# Altium schematic sheet 12V_VMOT_SUPPLY.SchDoc
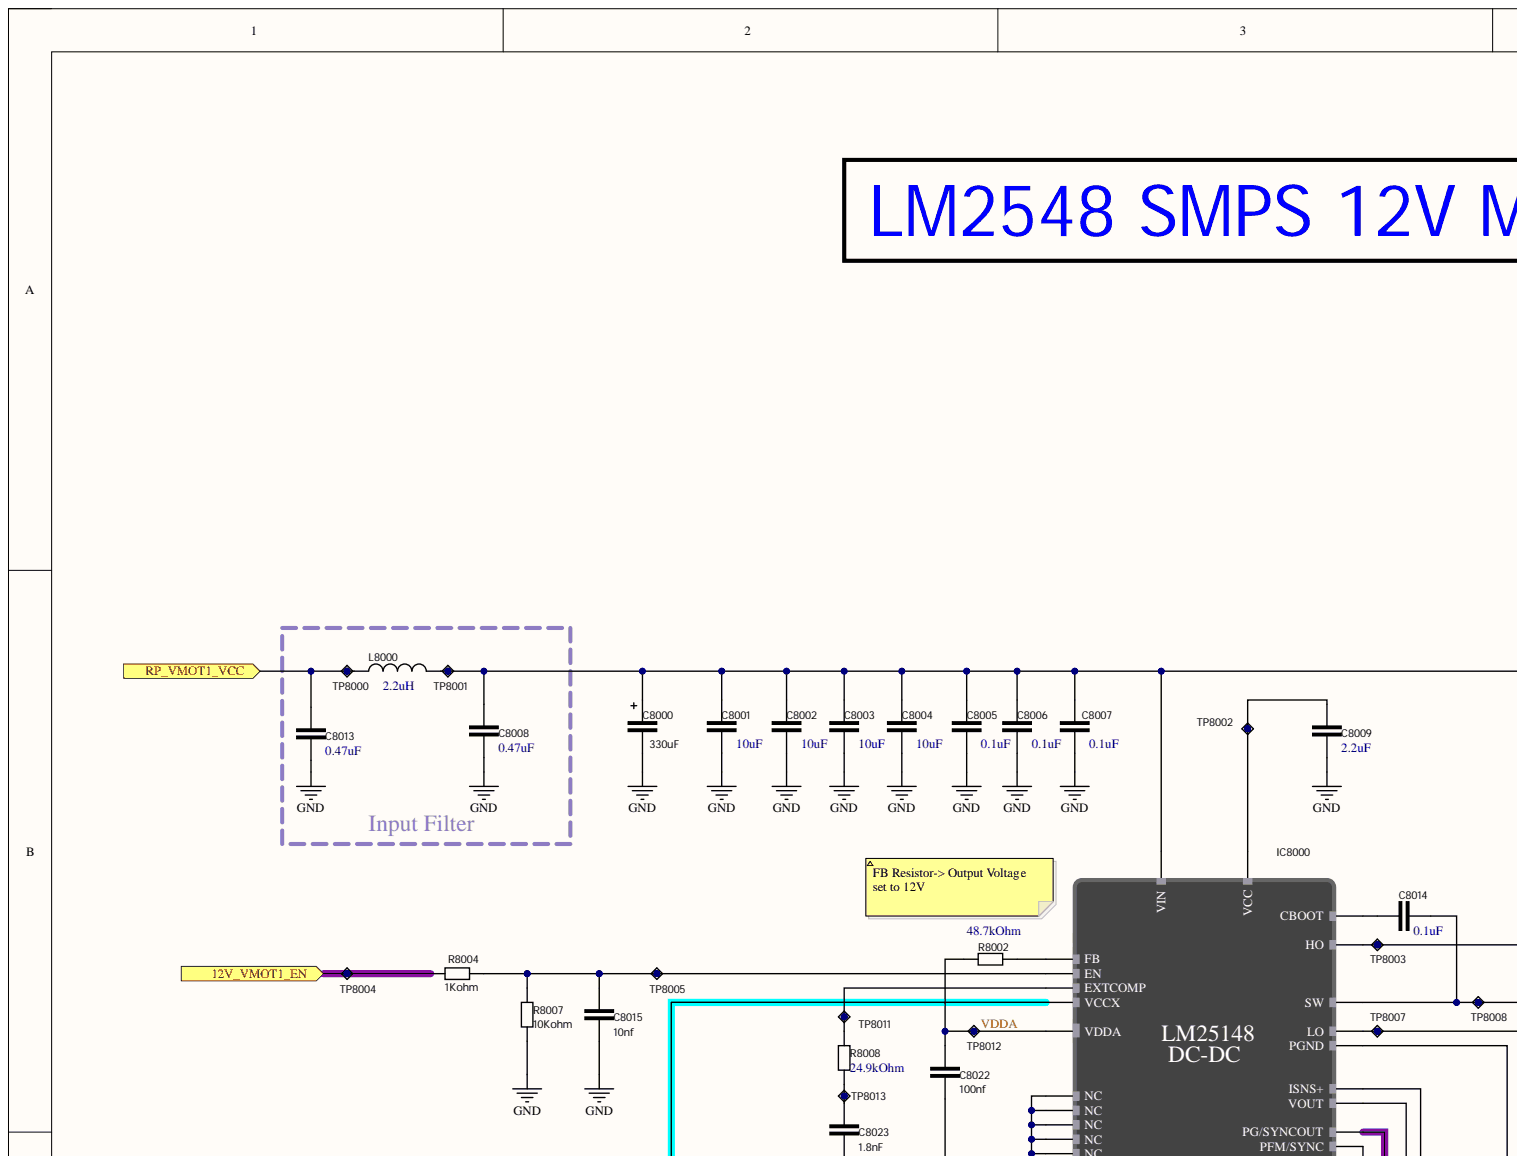
[diagram p0: 12V_VMOT_SUPPLY.SchDoc, top left]
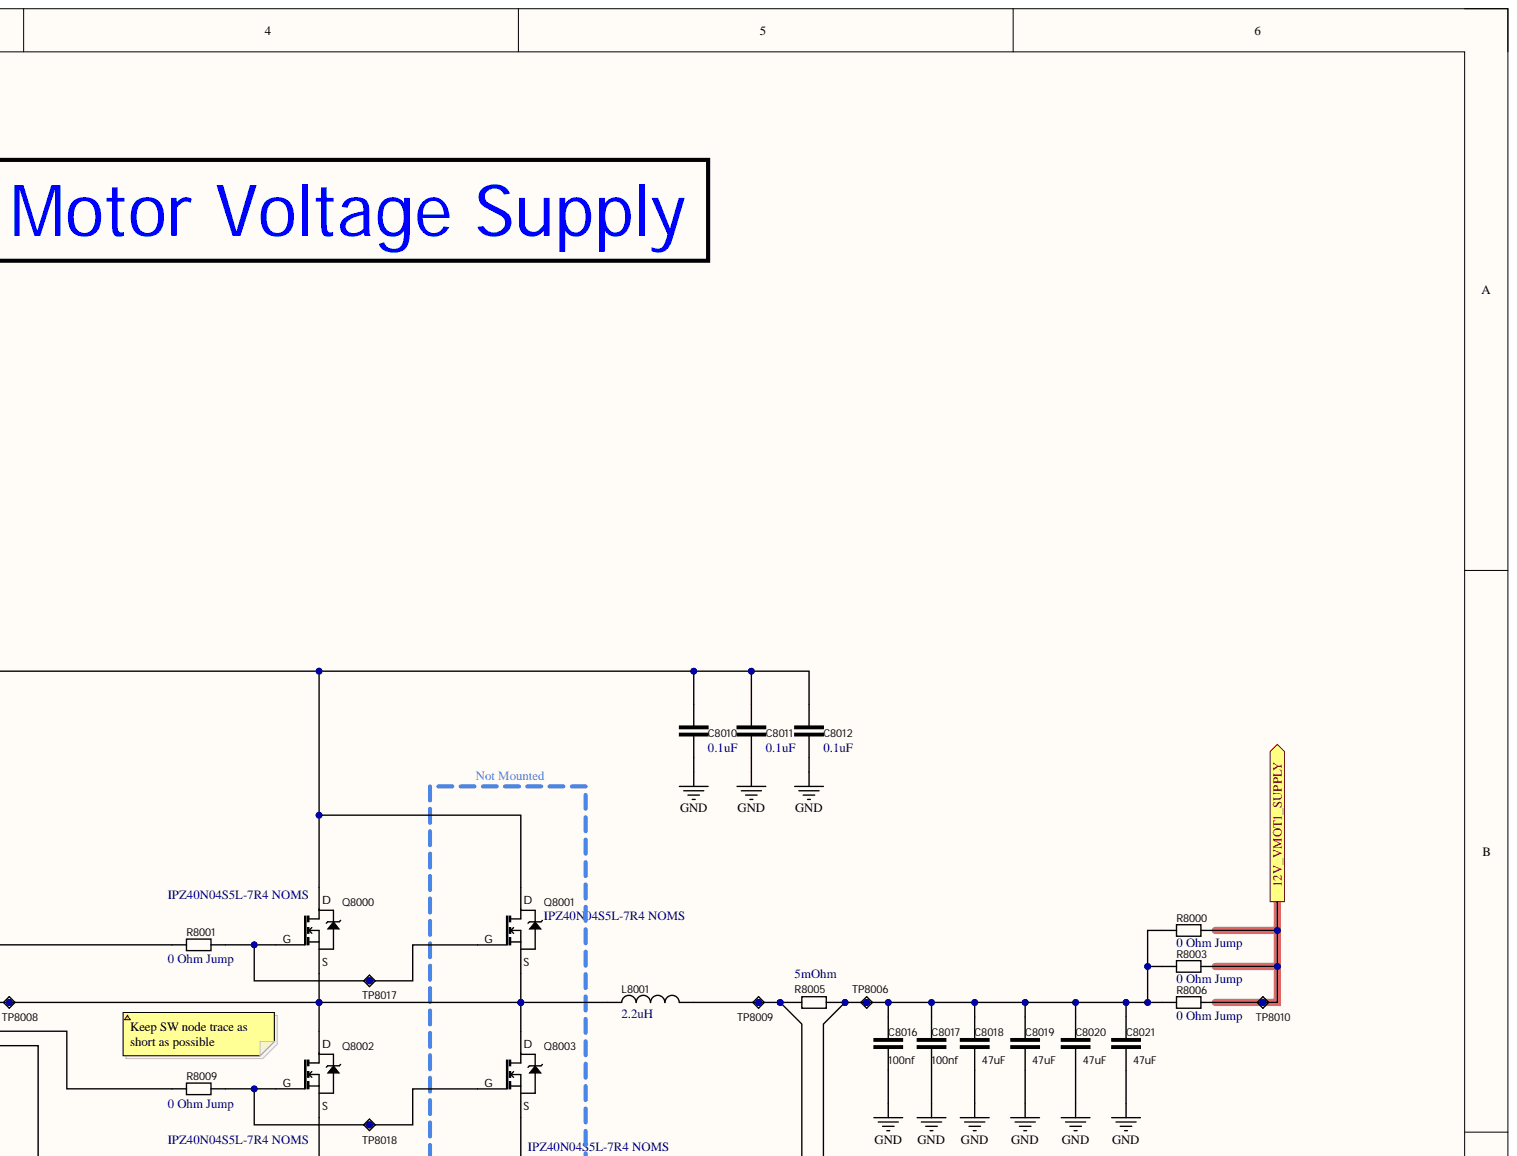
[diagram p1: 12V_VMOT_SUPPLY.SchDoc, top right]
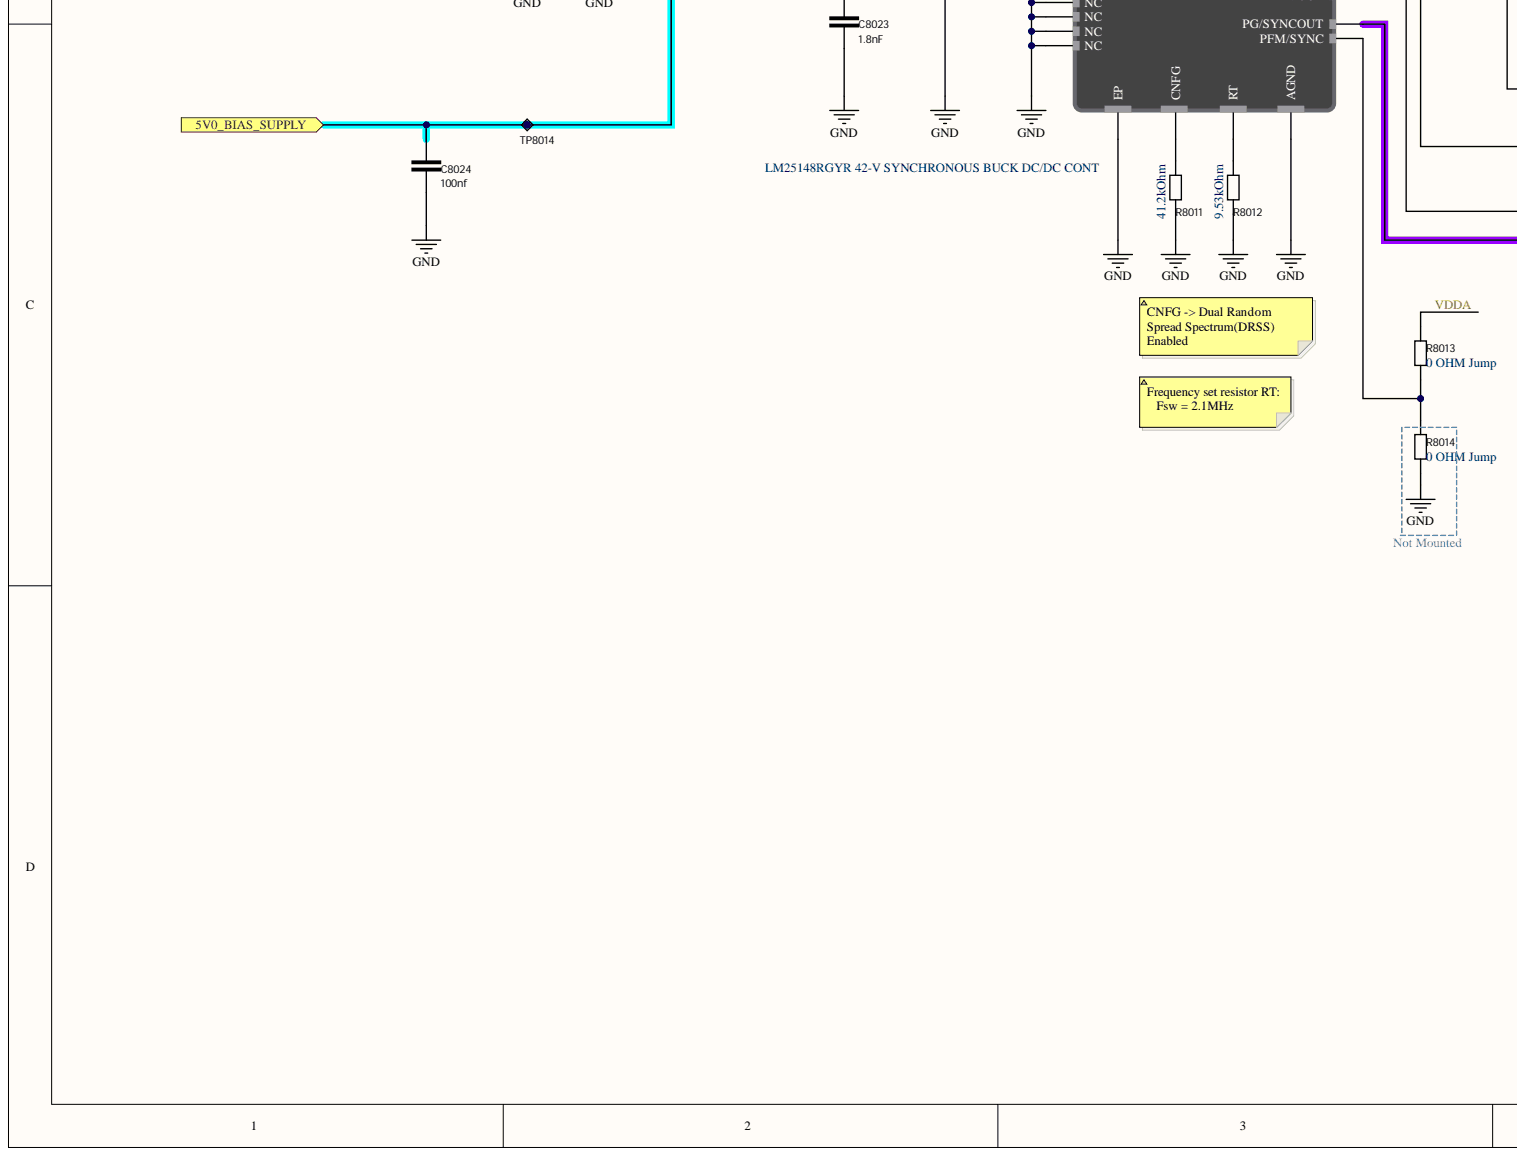
[diagram p2: 12V_VMOT_SUPPLY.SchDoc, bottom left]
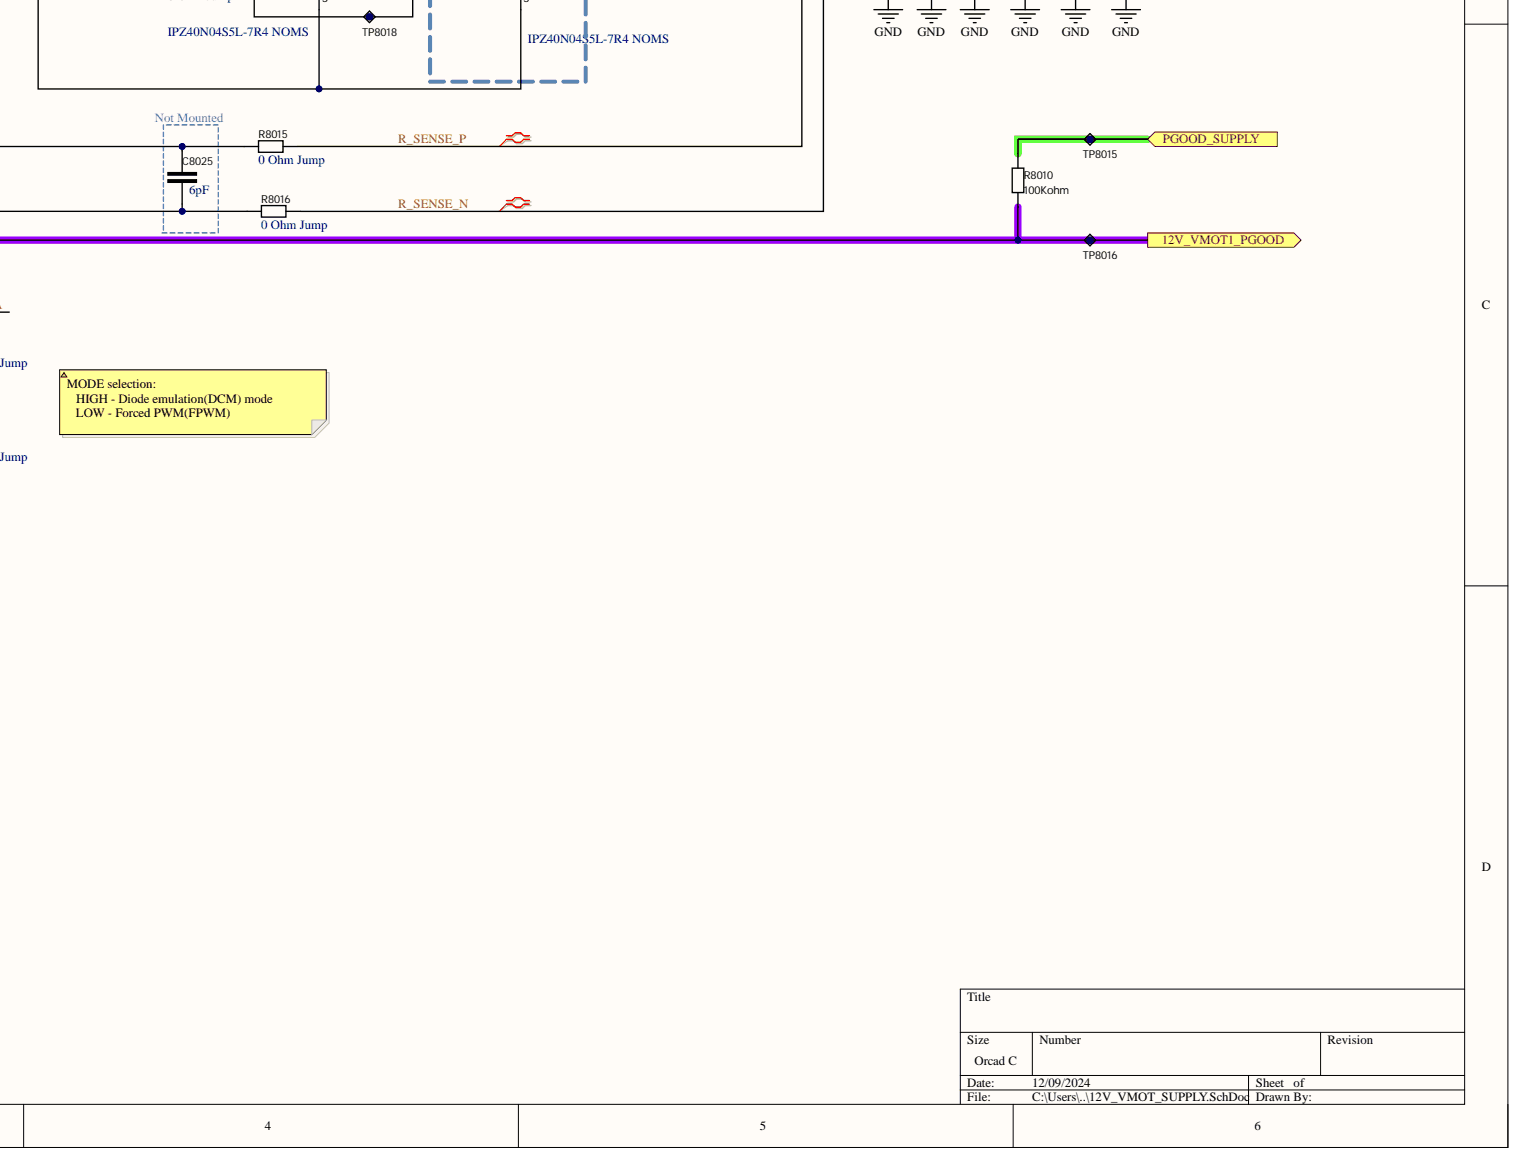
[diagram p3: 12V_VMOT_SUPPLY.SchDoc, bottom right]

## Parts
C8000  330uF  [CAPAE109103X103M]
C8001  10uF  [CAP_1206]
C8002  10uF  [CAP_1206]
C8003  10uF  [CAP_1206]
C8004  10uF  [CAP_1206]
C8005  0.1uF  [CAP_0603]
C8006  0.1uF  [CAP_0603]
C8007  0.1uF  [CAP_0603]
C8008  0.47uF  [CAP_0805]
C8009  2.2uF  [CAP_0603]
C8010  0.1uF  [CAP_0603]
C8011  0.1uF  [CAP_0603]
C8012  0.1uF  [CAP_0603]
C8013  0.47uF  [CAP_0805]
C8014  0.1uF  [CAP_0603]
C8015  10nf  [CAP_0603]
C8016  100nf  [CAP_0603]
C8017  100nf  [CAP_0603]
C8018  47uF  [CAP_1210]
C8019  47uF  [CAP_1210]
C8020  47uF  [CAP_1210]
C8021  47uF  [CAP_1210]
C8022  100nf  [CAP_0603]
C8023  1.8nF  [CAP_0603]
C8024  100nf  [CAP_0603]
C8025  6pF  [CAP_0402]
IC8000  LM25148RGYR 42-V SYNCHRONOUS BUCK DC/DC CONT  [VQFN25_RGY_TEX]
L8000  2.2uH  [INDP2523X23N_4040]
L8001  2.2uH  [INDP2523X23N_4040]
Q8000  IPZ40N04S5L-7R4 NOMS  [PG-TSDSON-8-32]
Q8001  IPZ40N04S5L-7R4 NOMS  [PG-TSDSON-8-32]
Q8002  IPZ40N04S5L-7R4 NOMS  [PG-TSDSON-8-32]
Q8003  IPZ40N04S5L-7R4 NOMS  [PG-TSDSON-8-32]
R8000  0 Ohm Jump  [RES_1206]
R8001  0 Ohm Jump  [RES_0402]
R8002  48.7kOhm  [RES_0603]
R8003  0 Ohm Jump  [RES_1206]
R8004  1Kohm  [RES_0603]
R8005  5mOhm  [RES_0508_wide]
R8006  0 Ohm Jump  [RES_1206]
R8007  10Kohm  [RES_0603]
R8008  24.9kOhm  [RES_0603]
R8009  0 Ohm Jump  [RES_0402]
R8010  100Kohm  [RES_0603]
R8011  41.2kOhm  [RES_0603]
R8012  9.53kOhm  [RES_0603]
R8013  0 OHM Jump  [RES_0603]
R8014  0 OHM Jump  [RES_0603]
R8015  0 Ohm Jump  [RES_0402]
R8016  0 Ohm Jump  [RES_0402]
TP8000  Test point  [TestPoint]  (value not drawn)
TP8001  Test point  [TestPoint]  (value not drawn)
TP8002  Test point  [TestPoint]  (value not drawn)
TP8003  Test point  [TestPoint]  (value not drawn)
TP8004  Test point  [TestPoint]  (value not drawn)
TP8005  Test point  [TestPoint]  (value not drawn)
TP8006  Test point  [TestPoint]  (value not drawn)
TP8007  Test point  [TestPoint]  (value not drawn)
TP8008  Test point  [TestPoint]  (value not drawn)
TP8009  Test point  [TestPoint]  (value not drawn)
TP8010  Test point  [TestPoint]  (value not drawn)
TP8011  Test point  [TestPoint]  (value not drawn)
TP8012  Test point  [TestPoint]  (value not drawn)
TP8013  Test point  [TestPoint]  (value not drawn)
TP8014  Test point  [TestPoint]  (value not drawn)
TP8015  Test point  [TestPoint]  (value not drawn)
TP8016  Test point  [TestPoint]  (value not drawn)
TP8017  Test point  [TestPoint]  (value not drawn)
TP8018  Test point  [TestPoint]  (value not drawn)

## Ports
5V0_BIAS_SUPPLY, 12V_VMOT1_EN, 12V_VMOT1_PGOOD, 12V_VMOT1_SUPPLY, PGOOD_SUPPLY, RP_VMOT1_VCC

## Nets
GND: C8000.1 C8001.1 C8002.2 C8003.2 C8004.2 C8005.2 C8006.2 C8007.1 C8008.1 C8009.1 C8010.1 C8011.1 C8012.2 C8013.1 C8015.1 C8016.1 C8017.1 C8018.1 C8019.1 C8020.1 C8021.1 C8022.1 C8023.1 C8024.1 IC8000.1(NC) IC8000.2(NC) IC8000.7(AGND) IC8000.22(NC) IC8000.23(NC) IC8000.24(NC) IC8000.25(EP) R8007.1 R8011.1 R8012.1 R8014.1
5V0_BIAS_SUPPLY: C8024.2 IC8000.16(VCCX) TP8014.1 ; port 5V0_BIAS_SUPPLY
12V_VMOT1_EN: R8004.1 TP8004.1 ; port 12V_VMOT1_EN
12V_VMOT1_PGOOD: IC8000.17(PG/SYNCOUT) R8010.1 TP8016.1 ; port 12V_VMOT1_PGOOD
12V_VMOT1_SUPPLY: R8000.2 R8003.2 R8006.2 TP8010.1 ; port 12V_VMOT1_SUPPLY
PGOOD_SUPPLY: R8010.2 TP8015.1 ; port PGOOD_SUPPLY
R_SENSE_8_N: C8016.2 C8017.2 C8018.2 C8019.2 C8020.2 C8021.2 R8000.1 R8003.1 R8005.2 R8006.1 R8016.2 TP8006.1  [also labelled R_SENSE_N]
R_SENSE_8_P: L8001.2 R8005.1 R8015.2 TP8009.1  [also labelled R_SENSE_P]
RP_VMOT1_VCC: C8013.2 L8000.1 TP8000.1 ; port RP_VMOT1_VCC
VDDA_8: C8022.2 IC8000.8(VDDA) R8002.1 R8013.2 TP8012.1  [also labelled VDDA]
NetC8000_2: C8000.2 C8001.2 C8002.1 C8003.1 C8004.1 C8005.1 C8006.1 C8007.2 C8008.2 C8010.2 C8011.2 C8012.1 IC8000.12(VIN) L8000.2 Q8000.D Q8001.D TP8001.1
NetC8009_2: C8009.2 IC8000.9(VCC) TP8002.1
NetC8014_1: C8014.1 IC8000.14(SW) L8001.1 Q8000.S Q8001.S Q8002.D Q8003.D TP8008.1
NetC8014_2: C8014.2 IC8000.15(CBOOT)
NetC8015_2: C8015.2 IC8000.19(EN) R8004.2 R8007.2 TP8005.1
NetC8023_2: C8023.2 R8008.1 TP8013.1
NetC8025_1: C8025.1 IC8000.21(VOUT) R8016.1
NetC8025_2: C8025.2 IC8000.20(ISNS+) R8015.1
NetIC8000_3: IC8000.3(CNFG) R8011.2
NetIC8000_4: IC8000.4(RT) R8012.2
NetIC8000_5: IC8000.5(EXTCOMP) R8008.2 TP8011.1
NetIC8000_6: IC8000.6(FB) R8002.2
NetIC8000_10: IC8000.10(PGND) Q8002.S Q8003.S
NetIC8000_11: IC8000.11(LO) R8009.1 TP8007.1
NetIC8000_13: IC8000.13(HO) R8001.1 TP8003.1
NetIC8000_18: IC8000.18(PFM/SYNC) R8013.1 R8014.2
NetQ8000_G: Q8000.G Q8001.G R8001.2 TP8017.1
NetQ8002_G: Q8002.G Q8003.G R8009.2 TP8018.1

## Library data of the parts
C8000 = C000031: 330 µF 35 V Aluminum Electrolytic Capacitors Radial, Can - SMD 90mOhm @ 100kHz 2000 Hrs @ 105°C; capaticanse: 330uF; Component Kind: Standard; ComponentLink1Description: digikey; ComponentLink1URL: https://www.digikey.com/en/products/detail/nic-components-corp/NACZ331M35V10X10-5TR15F/22237831?s=N4IgjCBcoGwJxVAYygMwIYBsDOBTANCAPZQDaIALAAxwDMdIAuoQA4AuUIAymwE4CWAOwDmIAL6EA7ACYKiECkgYcBYmRDS4cSfCasOkbnyGiJGqhQTQFaLHkIlI5WrSoACAK0AxPSHacAVUF%2BNgB5VABZXHRsAFdeXHFCaSpJK2RbFQd1AFZ3ADUQQloct0LmPwMQIJDwqJj4xLMAWml5RT5Y1UdyHKYxMzanBXQWdCQQol5%2BoA; DigiKey part number: 4988-NACZ331M35V10X10.5TR15FCT-ND; Library Name: CapacitorsIntegrated.IntLib
C8001-C8004 = C000017: CAP CER 10UF 50V X7R 1206; capacitance: 10uF; Component Kind: Standard; ComponentLink1Description: DigiKey; ComponentLink1URL: https://www.digikey.com/en/products/detail/samsung-electro-mechanics/CL31B106KBHNNNE/5961251; DigiKey Part Number: 1276-6767-1-ND; Library Name: CapacitorsIntegrated.IntLib
C8005-C8007 C8010-C8012 C8014 = C000018: CAP CER 0.1UF 50V X7R 0603; capacitance: 0.1uF; Component Kind: Standard; ComponentLink1Description: DigiKey; ComponentLink1URL: https://www.digikey.com/en/products/detail/samsung-electro-mechanics/CL10B104KB8NNNC/3886658; DigiKey Part Number: 1276-1000-1-ND; Library Name: CapacitorsIntegrated.IntLib
C8008 C8013 = C000030: 0.47 µF ±10% 50V Ceramic Capacitor X7R 0805 (2012 Metric); capacitance: 0.47uF; Component Kind: Standard; ComponentLink1Description: DigiKey; ComponentLink1URL: https://www.digikey.com/en/products/detail/murata-electronics/GRM21BR71H474KA88L/702592; DigiKey Part Number: 490-3328-1-ND; Library Name: CapacitorsIntegrated.IntLib
C8009 = C000014: CAP CER 2.2UF 16V X7S 0603; capaticanse: 2.2uF; Component Kind: Standard; ComponentLink1Description: Digikey; ComponentLink1URL: https://www.digikey.com/en/products/detail/murata-electronics/GRM188C71C225KE11D/4905311; Digikey Part Number: 490-12731-1-ND; Library Name: CapacitorsIntegrated.IntLib
C8015 = C000005: CAP CER 10nF 0603 25V X7R; capaticanse: 10nf; Component Kind: Standard; ComponentLink1Description: digikey; ComponentLink1URL: https://www.digikey.com/en/products/detail/kyocera-avx/06033C103MAT2A/1599370; Library Name: CapacitorsIntegrated.IntLib
C8016 C8017 C8022 C8024 = C000007: CAP CER 100nF 0603 25V X7R; capaticanse: 100nf; Component Kind: Standard; ComponentLink1Description: digikey; ComponentLink1URL: https://www.digikey.com/en/products/detail/kyocera-avx/06033C104K4T2A/8019250; Library Name: CapacitorsIntegrated.IntLib
C8018-C8021 = C000028: 47 µF ±20% 25V Ceramic Capacitor X5R 1210 (3225 Metric); capaticanse: 47uF; Component Kind: Standard; ComponentLink1Description: DigiKey; ComponentLink1URL: https://www.digikey.com/en/products/detail/taiyo-yuden/MSAST32MAB5476MPNDT1/16661322?s=N4IgTCBcDaILIGUCCCAqBmMckCECsALAOwBscACgHIAiqAjCALoC%2BQA; ComponentLink2Description: Mouser; ComponentLink2URL: https://eu.mouser.com/ProductDetail/TAIYO-YUDEN/MSAST32MAB5476MPNDT1?qs=tlsG%2FOw5FFjVcfO8xtWwSA%3D%3D; DigiKey Part Number: 587-MSAST32MAB5476MPNDT1CT-ND; Library Name: CapacitorsIntegrated.IntLib; Mouser Part Number: 963-MSAST32MAB5476MP
C8023 = C000027: 1800 pF ±10% 25V Ceramic Capacitor X7R 0603 (1608 Metric); capaticanse: 1.8nF; Component Kind: Standard; ComponentLink1Description: digikey; ComponentLink1URL: https://www.digikey.com/en/products/detail/kemet/C0603C182K3RAC7867/2200107; DigiKey Part Number: 399-15370-1-ND; Library Name: CapacitorsIntegrated.IntLib
C8025 = C000016: CAP CER 6PF 25V C0G/NP0 0402; capacitanse: 6pF; Component Kind: Standard; ComponentLink1Description: DigiKey; ComponentLink1URL: https://www.digikey.com/en/products/detail/kemet/CBR04C609D3GAC/18137865?s=N4IgjCBcpgLFoDGUBmBDANgZwKYBoQB7KAbXAFYBOAJlgHYQBdAgBwBcoQBlNgJwEsAdgHMQAXwJgAbAhDJI6bPiKkQAZkphyADiasOkbnyGiJIagAZYlWfMW4CxSGSkACFgDE9IdpwCqgvxsAPIoALI4aFgArrw44gQAtNS2UHzRyk5k5ExieUA; DigiKey Part Number: 399-CBR04C609D3GACCT-ND; Library Name: CapacitorsIntegrated.IntLib
IC8000 = LM25148RGYR: Buck Regulator Positive Output Step-Down DC-DC Controller IC 24-VQFN (5.5x3.5); Component Kind: Standard; ComponentLink1Description: DigiKey; ComponentLink1URL: https://www.digikey.com/en/products/detail/texas-instruments/LM25148RGYR/15857124; ComponentLink2Description: Mouser; ComponentLink2URL: https://eu.mouser.com/ProductDetail/Texas-Instruments/LM25148RGYR?qs=doiCPypUmgEViljGB5jAZw%3D%3D; DigiKey Part Number: 296-LM25148RGYRCT-ND; Library Name: IntegratedCircuits.IntLib; Mouser Part Number: 595-LM25148RGYR
L8000 L8001 = L000013: FIXED IND 2.2UH 12A 9 MOHM SMD; case: 4040; Component Kind: Standard; ComponentLink1Description: DigiKey; ComponentLink1URL: https://www.digikey.com/en/products/detail/vishay-dale/IHLP4040DZER2R2M01/1220692; DigiKey Part Number: 541-1299-1-ND; inductance: 2.2uH; Library Name: InductorIntegrated.IntLib
Q8000-Q8003 = 00000007: N-Channel 40 V 40A (Tc) 34W (Tc) Surface Mount PG-TSDSON-8; Component Kind: Standard; ComponentLink1Description: DigiKey; ComponentLink1URL: https://www.digikey.com/en/products/detail/infineon-technologies/IPZ40N04S5L7R4ATMA1/5960382; ComponentLink2Description: Mouser; ComponentLink2URL: https://eu.mouser.com/ProductDetail/Infineon-Technologies/IPZ40N04S5L7R4ATMA1?qs=RJIhqtkBntMJfptUOROQRQ%3D%3D; ComponentLink3Description: Footprint Drawing; ComponentLink3URL: https://www.infineon.com/cms/en/product/packages/PG-TSDSON/PG-TSDSON-8-32/#!downloads; DigiKey Part Number: IPZ40N04S5L7R4ATMA1CT-ND; Library Name: Miscellaneous_Lib1.IntLib; Mouser Part Number: 726-IPZ40N04S5L7R4AT
R8000 R8003 R8006 = R000012: 0 Ohms Jumper Chip Resistor 1206 (3216 Metric) Automotive AEC-Q200 Thick Film; Component Kind: Standard; ComponentLink1Description: DigiKey; ComponentLink1URL: https://www.digikey.com/en/products/detail/stackpole-electronics-inc/RMCF1206ZT0R00/1756906; DigiKey Part Number: RMCF1206ZT0R00CT-ND; Library Name: ResistorsIntegrated.IntLib; resistance: 0 Ohm Jump
R8001 R8009 R8015 R8016 = R000008: RES SMD 0 OHM JUMPER 1/10W 0402; Component Kind: Standard; ComponentLink1Description: DigiKey; ComponentLink1URL: https://www.digikey.com/en/products/detail/panasonic-electronic-components/ERJ-2GE0R00X/146727; DigiKey Part Number: P0.0JCT-ND; Library Name: ResistorsIntegrated.IntLib; resistance: 0 Ohm Jump
R8002 = R000018: 48.7 kOhms ±1% 0.1W, 1/10W Chip Resistor 0603 (1608 Metric) Automotive AEC-Q200 Thick Film; Component Kind: Standard; ComponentLink1Description: DigiKey; ComponentLink1URL: https://www.digikey.com/en/products/detail/stackpole-electronics-inc/RMCF0603FT48K7/1714073; DigiKey Part Number: RMCF0603FT48K7CT-ND; Library Name: ResistorsIntegrated.IntLib; resistance: 48.7kOhm
R8004 = R000004: RES 1K OHM 1% 1/10W 0603; Component Kind: Standard; ComponentLink1Description: digikey; ComponentLink1URL: https://www.digikey.com/en/products/detail/vishay-beyschlag-draloric-bc-components/MCT06030C1001FP500/2607821; Library Name: ResistorsIntegrated.IntLib; resistance: 1Kohm
R8005 = R000019: 5 mOhms ±1% 1W Chip Resistor Wide 0805 (2012 Metric), 0508 Automotive AEC-Q200, Current Sense Metal Foil; Component Kind: Standard; ComponentLink1Description: DigiKey; ComponentLink1URL: https://www.digikey.com/en/products/detail/susumu/KRL2012E-M-R005-F-T5/3738021?s=N4IgTCBcDaINICUAyYAMBGMBRAtAWRwVVQFYcAxHAFRJAF0BfIA; DigiKey Part Number: 408-1595-1-ND; Library Name: ResistorsIntegrated.IntLib; resistance: 5mOhm
R8007 = R000001: RES 10K OHM 1% 1/10W 0603; Component Kind: Standard; ComponentLink1Description: digikey; ComponentLink1URL: https://www.digikey.com/en/products/detail/vishay-beyschlag-draloric-bc-components/MCT06030C1002FP500/1850440; Library Name: ResistorsIntegrated.IntLib; resistance: 10Kohm
R8008 = R000017: 24.9 kOhms ±1% 0.1W, 1/10W Chip Resistor 0603 (1608 Metric) Automotive AEC-Q200 Thick Film; Component Kind: Standard; ComponentLink1Description: DigiKey; ComponentLink1URL: https://www.digikey.com/en/products/detail/panasonic-electronic-components/ERJ-3EKF2492V/196236; DigiKey Part Number: P24.9KHCT-ND; Library Name: ResistorsIntegrated.IntLib; resistance: 24.9kOhm
R8010 = R000006: RES 100K OHM 1% 1/10W 0603; Component Kind: Standard; ComponentLink1Description: digikey; ComponentLink1URL: https://www.digikey.com/en/products/detail/stackpole-electronics-inc/RMCF0603FG100K/1713543; Library Name: ResistorsIntegrated.IntLib; resistance: 100Kohm
R8011 = R000015: 41.2 kOhms ±1% 0.1W, 1/10W Chip Resistor 0603 (1608 Metric) Automotive AEC-Q200 Thick Film; Component Kind: Standard; ComponentLink1Description: DigiKey; ComponentLink1URL: https://www.digikey.com/en/products/detail/panasonic-electronic-components/ERJ-3EKF4122V/196347; DigiKey Part Number: P41.2KHCT-ND; Library Name: ResistorsIntegrated.IntLib; resistance: 41.2kOhm
R8012 = R000016: 9.53 kOhms ±1% 0.1W, 1/10W Chip Resistor 0603 (1608 Metric) Moisture Resistant Thick Film; Component Kind: Standard; ComponentLink1Description: DigiKey; ComponentLink1URL: https://www.digikey.com/en/products/detail/yageo/RC0603FR-079K53L/727421; DigiKey Part Number: 311-9.53KHRCT-ND; Library Name: ResistorsIntegrated.IntLib; resistance: 9.53kOhm
R8013 R8014 = R000007: RES 0 OHM JUMPER 1/10W 0603; Component Kind: Standard; ComponentLink1Description: DigiKey; ComponentLink1URL: https://www.digikey.com/en/products/detail/stackpole-electronics-inc/RMCF0603ZT0R00/1756908; Library Name: ResistorsIntegrated.IntLib; resistance: 0 OHM Jump; Supplier 1: Arrow Electronics; Supplier Part Number 1: RMCF0603ZT0R00
TP8000-TP8018 = TP002: Test point 1mm; Component Kind: Standard; Library Name: IntegratedCircuits.IntLib
